# Altium schematic sheet ACTIVATOR_AIR.SchDoc
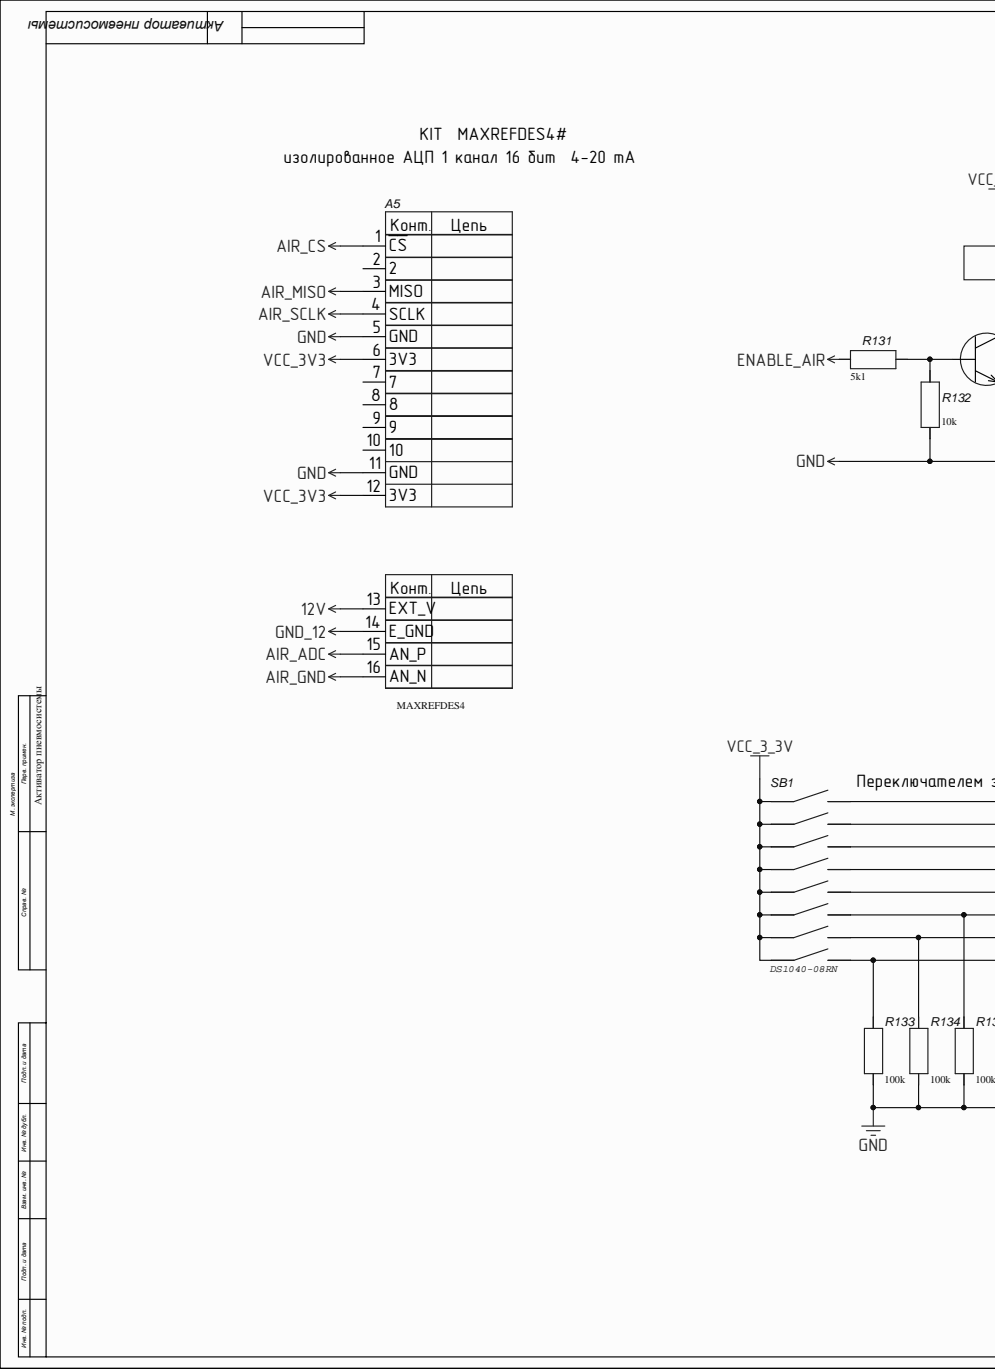
[diagram p0: ACTIVATOR_AIR.SchDoc, left]
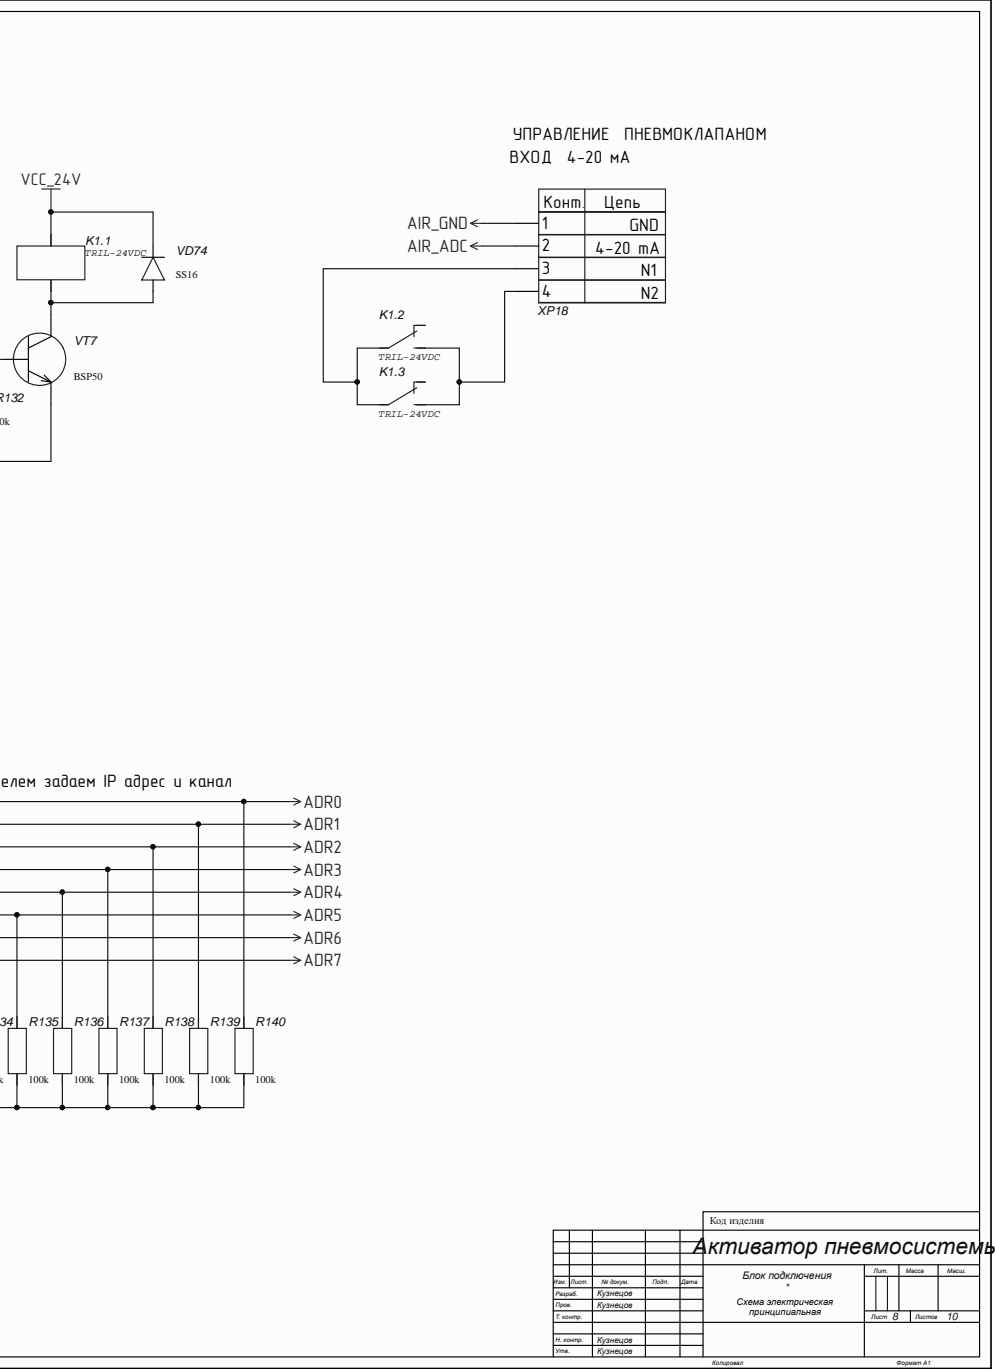
[diagram p1: ACTIVATOR_AIR.SchDoc, right]

## Parts
A5  MAXREFDES4  [MAXREFDES4]
K1  TRIL-24VDC  [Relay_TRIL]  (parts .1,.2,.3)
R131  5k1  [0805]
R132  10k  [0805]
R133  100k  [0805]
R134  100k  [0805]
R135  100k  [0805]
R136  100k  [0805]
R137  100k  [0805]
R138  100k  [0805]
R139  100k  [0805]
R140  100k  [0805]
SB1  DS1040-08RN  [DS1040-08RN]
VD74  SS16  [DO-214AC(SMA)]
VT7  BSP50  [SOT 223 (SC73, SOT-223)]
XP18  828801- 1  [828801- 1]  (value not drawn)

## Nets
12V: A5.13(EXT_V)
ADR0: R140.2 SB1.2
ADR1: R139.2 SB1.4
ADR2: R138.2 SB1.6
ADR3: R137.2 SB1.8
ADR4: R136.2 SB1.10
ADR5: R135.2 SB1.12
ADR6: R134.2 SB1.14
ADR7: R133.2 SB1.16
AIR_ADC: A5.15(AN_P) XP18.2
AIR_CS: A5.1(CS)
AIR_GND: A5.16(AN_N) XP18.1
AIR_MISO: A5.3(MISO)
AIR_SCLK: A5.4(SCLK)
ENABLE_AIR: R131.1
GND: A5.5(GND) A5.11(GND) R132.1 R133.1 R134.1 R135.1 R136.1 R137.1 R138.1 R139.1 R140.1 VT7.3
GND_12: A5.14(E_GND)
VCC_3_3V: SB1.1 SB1.3 SB1.5 SB1.7 SB1.9 SB1.11 SB1.13 SB1.15
VCC_3V3: A5.6(3V3) A5.12(3V3)
VCC_24V: K1.2 VD74.1
NetK1_1: K1.1 VD74.2 VT7.2
NetK1_3: K1.3 K1.6 XP18.3
NetK1_5: K1.5 K1.8 XP18.4
NetR131_2: R131.2 R132.2 VT7.1

Not connected: A5 2 7 8 9 10; K1 4 7

## Library data of the parts
A5 = MAXREFDES4: STM32F769 Discovery Kit STM32F7 MCU 32-Bit ARM®; Manufacturer: Maxim; Component Kind: Standard; ComponentLink1Description: Параметры; ComponentLink2Description: Сайт производителя; ManufacturerPartNumber: MAXREFDES4; Author: Eleman; Library Name: Eleman_Plc.SchLib
K1 = TRIL-24VDC: Реле; ManufacturerPartNumber: TRIL-24VDC-SD-2CM-R; Power\Voltage: 24V  8A; Component Kind: Standard; ComponentLink1Description: Параметры; ComponentLink2Description: Сайт производителя; Author: Rodnik SPE; ComponentLink1URL: C:\Demo\Datasheet\7914.pdf; Library Name: Eleman_Relay.SchLib
R131-R140 = R0805: Импортный постоянный углеродистый резистор; Manufacturer: Vishay Dale; Power\Voltage: 0.125W; Tolerance: 5%; Component Kind: Standard; ComponentLink1Description: Параметры; ComponentLink2Description: Сайт производителя; ManufacturerPartNumber: R0805; Корпус: SMD 0805; Author: Eleman; Library Name: Eleman_Resistor.SchLib
  R131: Value: 5k1
  R132: Value: 10k
  R133-R140: Value: 100k
SB1 = DS1040-08RN: Переключатель однополюсный многопозиционный; Component Kind: Standard; ComponentLink1Description: Параметры; ComponentLink2Description: Сайт производителя; ManufacturerPartNumber: DS1040-08RN; Author: Eleman; Library Name: Eleman_Discret.SchLib
VD74 = SS16: Диод  Schottky; Manufacturer: Fairchild/ON Semiconductor; Power\Voltage: 60V 1A; Tolerance: 5%; Корпус: DO-214AC; Component Kind: Standard; ComponentLink1Description: Параметры; ComponentLink2Description: Сайт производителя; ManufacturerPartNumber: SS16; Author: Eleman; Library Name: Eleman_Diods.SchLib
VT7 = BSP50: Транзистор NPN; Manufacturer: NXP USA Inc.; Power\Voltage: 45V/1A; Tolerance: 200MHz; Корпус: TO-261-4; Component Kind: Standard; ComponentLink1Description: Параметры; ComponentLink2Description: Сайт производителя; ManufacturerPartNumber: BSP50; Author: Eleman; Library Name: Eleman_Transistor.SchLib
XP18 = 828801- 1: Разъем; Manufacturer: TE Connectivity AMP Connectors; Rem: 4 - pin; Component Kind: Standard; ComponentLink1Description: Параметры; ComponentLink2Description: Сайт производителя; ManufacturerPartNumber: 828801- 1; Author: Eleman; ComponentLink1URL: C:\Demo\Datasheet; Library Name: Eleman_Connector.SchLib
